# Altium schematic sheet LORA.SchDoc
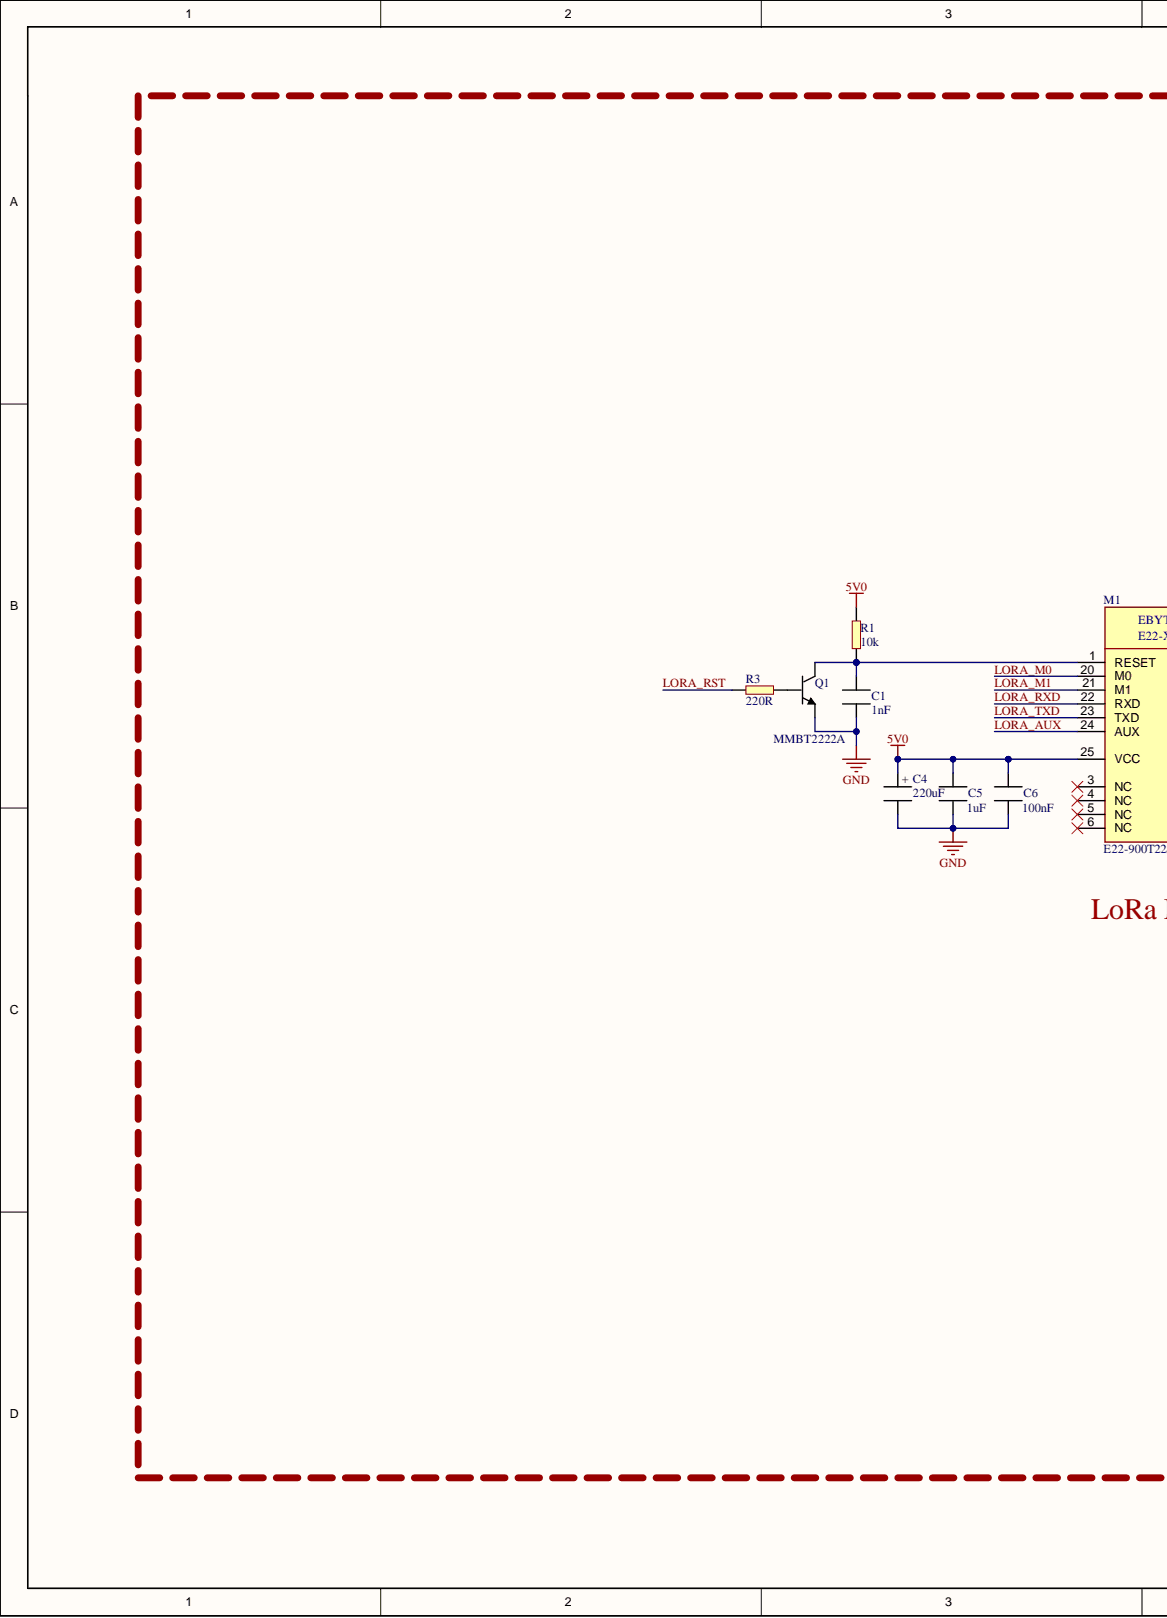
[diagram p0: LORA.SchDoc, left]
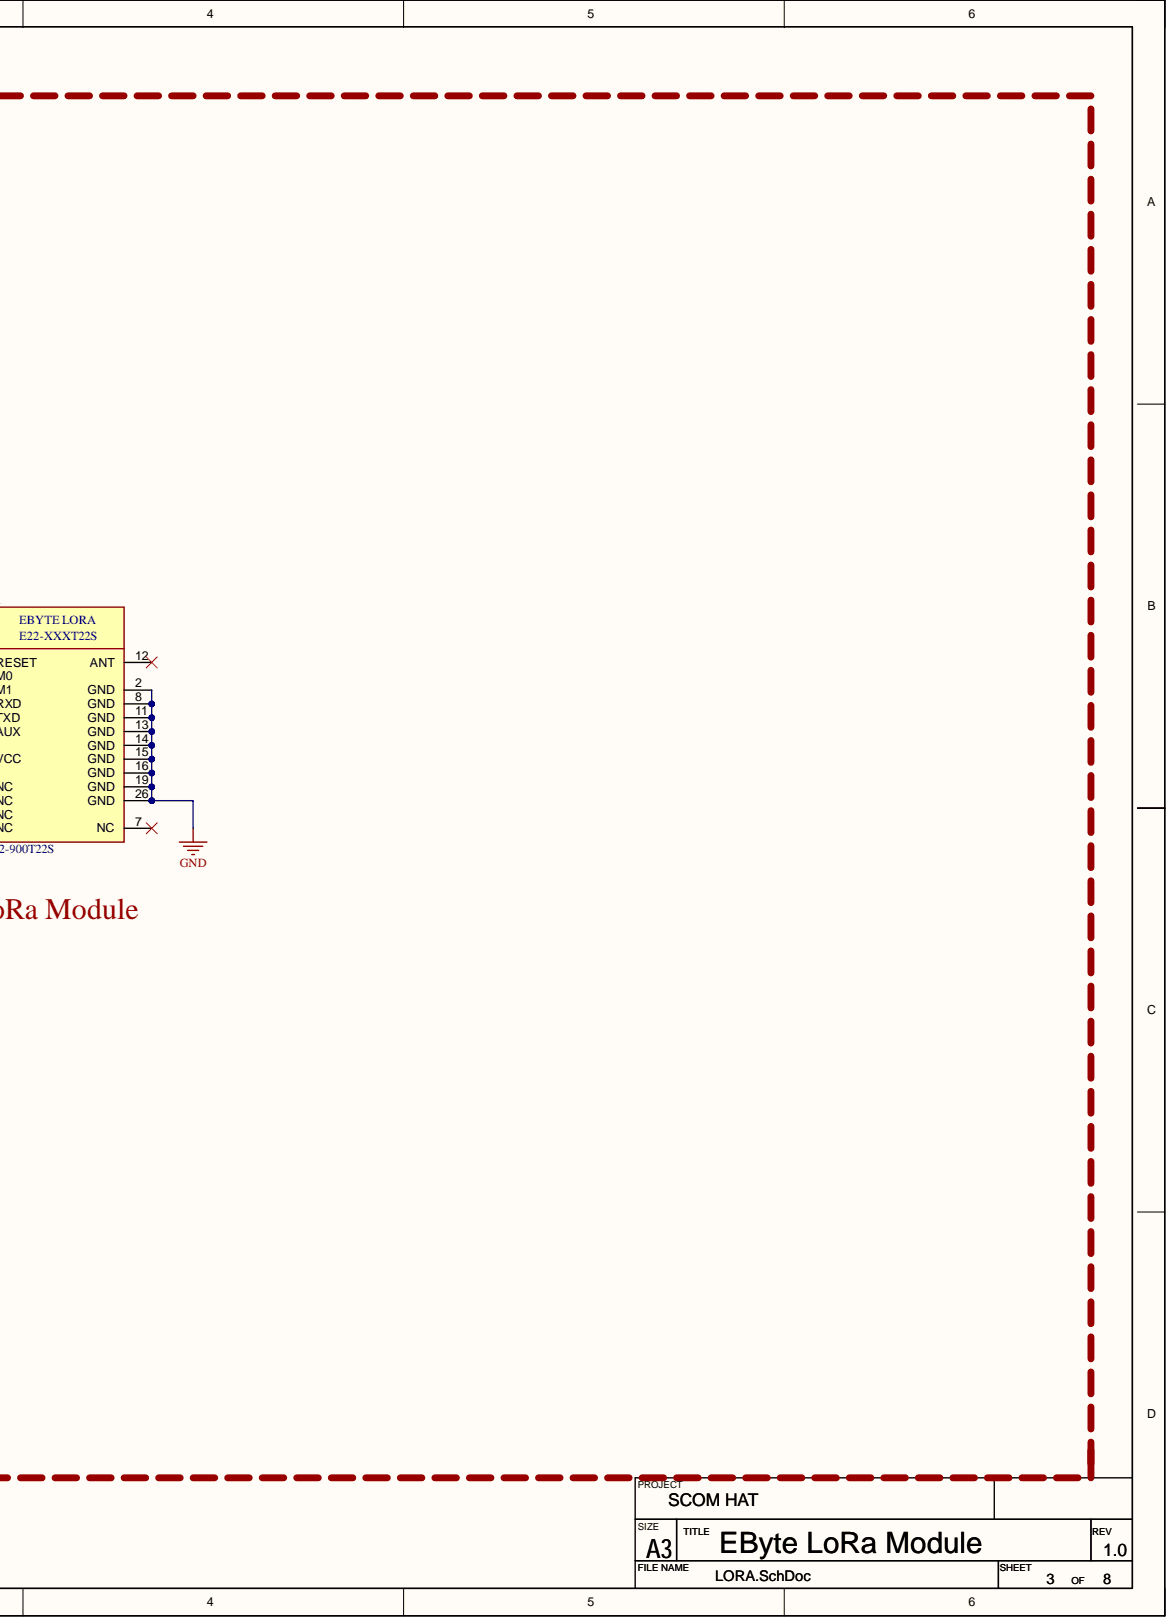
[diagram p1: LORA.SchDoc, right]

## Parts
C1  value 1nF  [CAPC2012X13L]  (hidden comment: MLCC_0805)  (no BOM)
C4  value 220uF  [CAP_D10_H16_P5 - Flat]  (hidden comment: ERP_102-2)
C5  value 1uF  [CAPC2012X13L]  (hidden comment: MLCC_0805)
C6  value 100nF  [CAPC2012X13L]  (hidden comment: MLCC_0805)
M1  E22-XXXT22S  value E22-900T22S  [E22-XXXT22S]
Q1  value MMBT2222A  [MMBT2222]  (hidden comment: ERP_105-1)
R1  value 10k  [RES_0603]  (hidden comment: ERP_101-1)
R3  value 220R  [RES_0603]  (hidden comment: ERP_101-1)

## Nets
5V0: C4.1 C5.1 C6.1 M1.25(VCC) R1.2
GND: C1.2 C4.2 C5.2 C6.2 M1.2(GND) M1.8(GND) M1.11(GND) M1.13(GND) M1.14(GND) M1.15(GND) M1.16(GND) M1.19(GND) M1.26(GND) Q1.2
LORA_AUX: M1.24(AUX)
LORA_M0: M1.20(M0)
LORA_M1: M1.21
LORA_RST: R3.2
LORA_RXD: M1.22(RXD)
LORA_TXD: M1.23(TXD)
NetC1_1: C1.1 M1.1(RESET) Q1.3 R1.1
NetQ1_1: Q1.1 R3.1

Not connected: M1 3(NC) 4(NC) 5(NC) 6(NC) 7(NC) 12(ANT)

## Library data of the parts
C1 C6 = MLCC_0805: Chip Capacitor, 2-Leads, Body 2.00x1.25mm, IPC High Density; Library Name: Capacitor.SchLib; Package: 0805
  C6: Component Kind: Standard
C4 = CAP_D10_H16_P5: Electrolytic Capacitor Through Hole D10 P5.0; Component Kind: Standard; Library Name: ElectrolyticCapacitor.SchLib; Package: 10X5
C5 = MLCC_0805: 1uF 105 0805 (2012 metric) 50V X7R MLCC SMD; Component Kind: Standard; Library Name: Capacitor.SchLib; Package: 0805
M1 = E22-XXXT22S: E22 EByte LoRa Module; Component Kind: Standard; Library Name: WirelessModule.SchLib
Q1 = MMBT2222: MMBT2222A; Component Kind: Standard; Library Name: BJT.SchLib; Package: SOT23
R1 R3 = RES_0603: Component Kind: Standard; Description: RES_0603; Library Name: Resistor.SchLib; Package: 0603
